# Altium schematic sheet Schematic.SchDoc(Schematic)
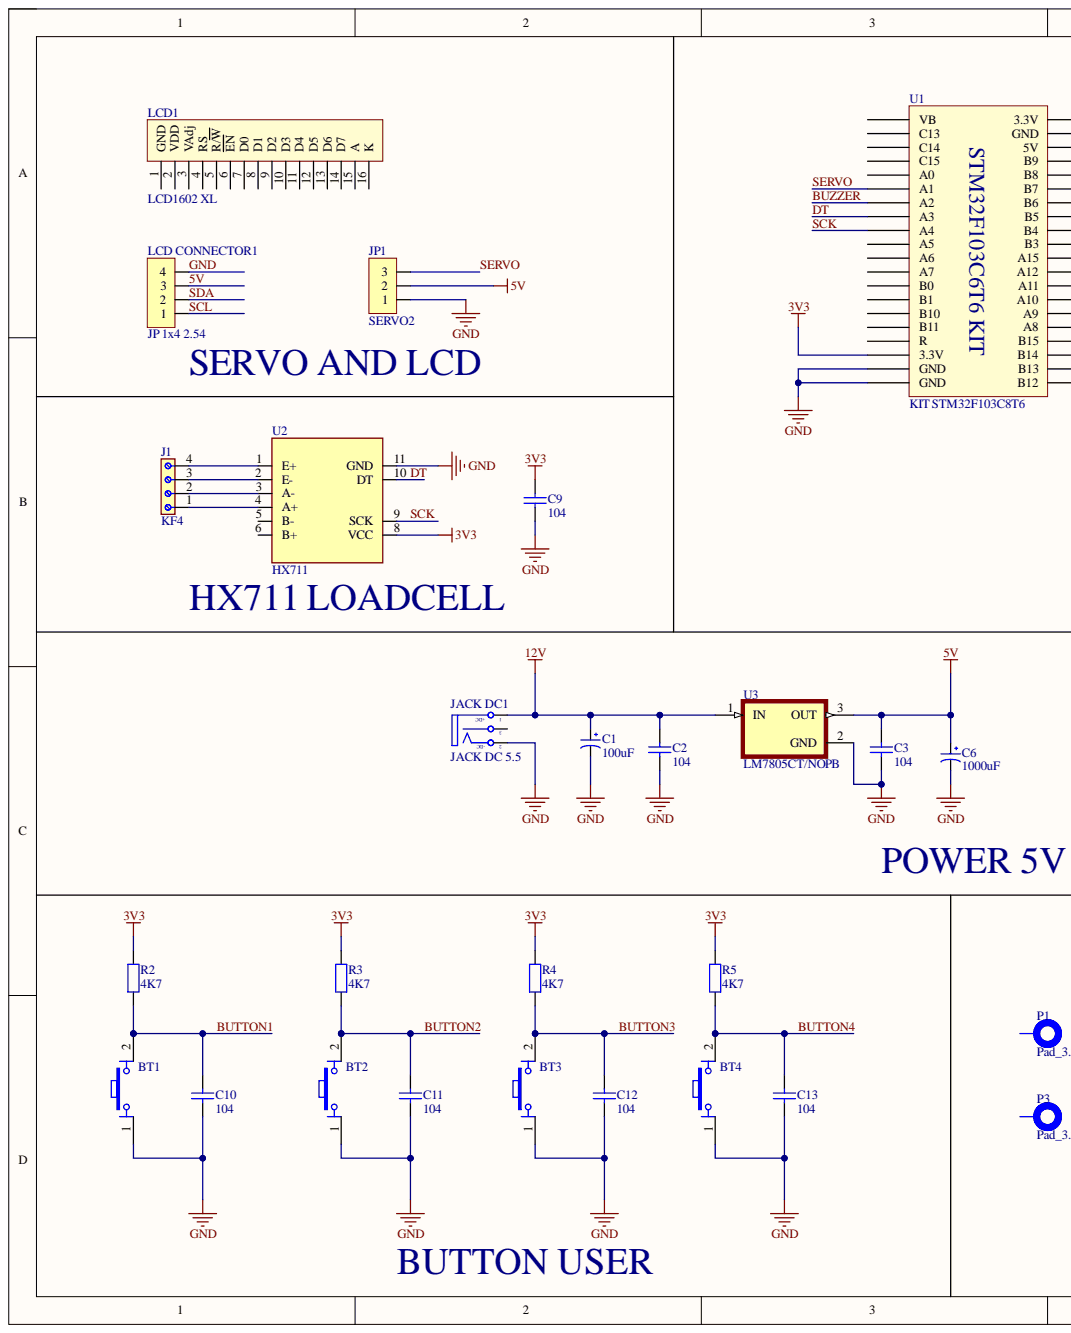
[diagram p0: Schematic.SchDoc, left]
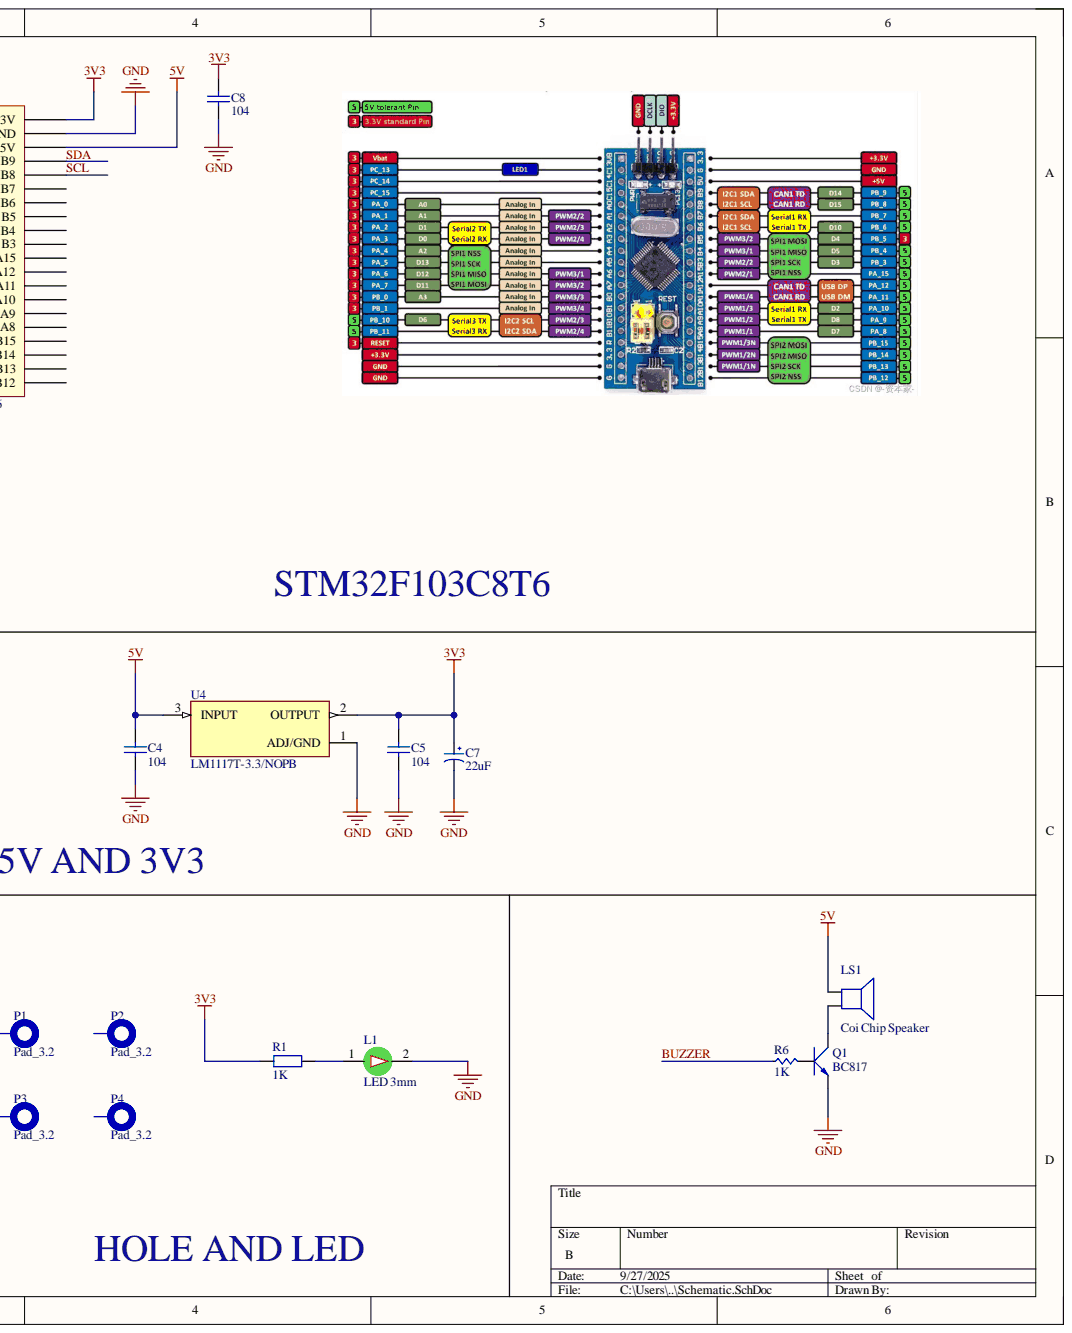
[diagram p1: Schematic.SchDoc, right]

## Parts
BT1  Nut Nhan 4 Chan Cam 6x6  [Nut Nhan 4 Chan Cam 6x6]  (value not drawn)
BT2  Nut Nhan 4 Chan Cam 6x6  [Nut Nhan 4 Chan Cam 6x6]  (value not drawn)
BT3  Nut Nhan 4 Chan Cam 6x6  [Nut Nhan 4 Chan Cam 6x6]  (value not drawn)
BT4  Nut Nhan 4 Chan Cam 6x6  [Nut Nhan 4 Chan Cam 6x6]  (value not drawn)
C1  100uF  [tu hoa 5mm]
C2  104  [tu gom]
C3  104  [tu gom]
C4  104  [tu gom]
C5  104  [tu gom]
C6  1000uF  [tu hoa 10mm]
C7  22uF  [tu hoa 5mm]
C8  104  [tu gom]
C9  104  [tu gom]
C10  104  [tu gom]
C11  104  [tu gom]
C12  104  [tu gom]
C13  104  [tu gom]
J1  KF4  [4P 5.08]
JACK_DC1  JACK DC 5.5  [JACK DC 5.5]
JP1  SERVO2  [JP 1x3 DT]
L1  LED 3mm  [LED-3MM-GREEN]
LCD1  LCD1602 XL  [LCD1602 with Jump]
LCD_CONNECTOR1  JP 1x4 2.54  [JP 1x4 DC]
LS1  Coi Chip Speaker  [Coi Chip Speacker]
P1  Pad_3.2  [PCBComponent_1]
P2  Pad_3.2  [PCBComponent_1]
P3  Pad_3.2  [PCBComponent_1]
P4  Pad_3.2  [PCBComponent_1]
Q1  BC817  [SOT-23]
R1  1K  [tro vach 1/2w]
R2  4K7  [tro vach 1/4w]
R3  4K7  [tro vach 1/4w]
R4  4K7  [tro vach 1/4w]
R5  4K7  [tro vach 1/4w]
R6  1K  [RES 0805]
U1  KIT STM32F103C8T6  [STM32F103 KIT]
U2  HX711  [HX711]
U3  LM7805CT/NOPB  [LM7805]
U4  LM1117T-3.3/NOPB  [TO254P1054X470X1955-3]

## Nets
3V3: C5.1 C7.1 C8.1 C9.1 R1.1 R2.2 R3.2 R4.2 R5.2 U1.20(3.3V) U1.38(3.3V) U2.8(VCC) U4.2
12V: C1.1 C2.1 JACK_DC1.1 U3.1
5V: C3.1 C4.1 C6.1 JP1.2 LCD_CONNECTOR1.3 LS1.1 U1.18(5V) U3.3 U4.3
BUTTON1: BT1.2 C10.1 R2.1
BUTTON2: BT2.2 C11.1 R3.1
BUTTON3: BT3.2 C12.1 R4.1
BUTTON4: BT4.2 C13.1 R5.1
BUZZER: R6.1 U1.27(A2)
DT: U1.28(A3) U2.10(DT)
GND: BT1.1 BT2.1 BT3.1 BT4.1 C1.2 C2.2 C3.2 C4.2 C5.2 C6.2 C7.2 C8.2 C9.2 C10.2 C11.2 C12.2 C13.2 JACK_DC1.2 JP1.1 L1.2 LCD_CONNECTOR1.4 Q1.2 U1.19(GND) U1.39(GND) U1.40(GND) U2.11(GND) U3.2 U4.1
SCK: U1.29(A4) U2.9(SCK)
SCL: LCD_CONNECTOR1.1 U1.16(B8)
SDA: LCD_CONNECTOR1.2 U1.17(B9)
SERVO: JP1.3 U1.26(A1)
NetJ1_1: J1.1 U2.4(A+)
NetJ1_2: J1.2 U2.3(A-)
NetJ1_3: J1.3 U2.2(E-)
NetJ1_4: J1.4 U2.1(E+)
NetL1_1: L1.1 R1.2
NetLS1_2: LS1.2 Q1.3
NetQ1_1: Q1.1 R6.2

Not connected: JACK_DC1 3; LCD1 1(GND) 2(VDD) 3(VAdj) 4(RS) 5(R/W) 6(EN) 7(D0) 8(D1) 9(D2) 10(D3) 11(D4) 12(D5) 13(D6) 14(D7) 15(A) 16(K); P1 0; P2 0; P3 0; P4 0; U1 1(B12) 2(B13) 3(B14) 4(B15) 5(A8) 6(A9) 7(A10) 8(A11) 9(A12) 10(A15) 11(B3) 12(B4) 13(B5) 14(B6) 15(B7) 21(VB) 22(C13) 23(C14) 24(C15) 25(A0) 30(A5) 31(A6) 32(A7) 33(B0) 34(B1) 35(B10) 36(B11) 37(R); U2 5(B-) 6(B+)

## Library data of the parts
BT1-BT4 = Nut Nhan 4 Chan Cam 6x6: Nut Nhan 4 Chan 6x6mm; Ma_Hang: MH004584; Ten: Nút Nhấn 4 Chân 6x6x5MM DIP; Component Kind: Standard; Library Name: Altium_Lib_by_KhueNguyenCreator.IntLib
C1 = Tu Hoa 100uF 25V 6x11: Cắm; Don_Vi: Con; Ma_Hang: MH001351; So_Chan: 2; Ten_Linh_Kien: Tụ Hóa 100uF 25V 6x11MM; Chung_Loai: Cắm; Component Kind: Standard; Library Name: Altium_Lib_by_KhueNguyenCreator.IntLib
C2-C5 C8-C13 = Tu gom 104 50V: Cắm; Don_Vi: Con; Ma_Hang: MH004761; So_Chan: 2; Ten_Linh_Kien: Tụ gốm 104 100nF 50V; Chung_Loai: Cắm; Component Kind: Standard; Library Name: Altium_Lib_by_KhueNguyenCreator.IntLib
C6 = Tu Hoa 1000uF 10V 10x12: Cắm; Don_Vi: Con; Ma_Hang: MH006614; So_Chan: 2; Ten_Linh_Kien: Tụ Hóa 1000uF 10V 10x12MM; Chung_Loai: Cắm; Component Kind: Standard; Library Name: Altium_Lib_by_KhueNguyenCreator.IntLib
C7 = Tu Hoa 22uF 25V 5x11: Cắm; Don_Vi: Con; Ma_Hang: MH006858; So_Chan: 2; Ten_Linh_Kien: Tụ Hóa 22uF 25V; Chung_Loai: Cắm; Component Kind: Standard; Library Name: Altium_Lib_by_KhueNguyenCreator.IntLib
J1 = 691244710004: 4 Position Wire to Board Terminal Block Horizontal with Board 0.197 (5.00mm) Through Hole; MF: WÃ¼rth Elektronik; Check_prices: https://www.snapeda.com/parts/691244710004/W%25C3%25BCrth+Elektronik+Midcom/view-part/?ref=eda; Component Kind: Standard; Library Name: 691244710004.IntLib; MP: 691244710004; Package: None; SnapEDA_Link: https://www.snapeda.com/parts/691244710004/W%25C3%25BCrth+Elektronik+Midcom/view-part/?ref=snap
JACK_DC1 = JACK DC 5.5: Value: Jac DC; Component Kind: Standard; Description: JACK DC 5.5; Library Name: Altium_Lib_by_KhueNguyenCreator.IntLib
JP1 = JP 1x3 2.54 Duc Thang: Cắm; Don_Vi: Con; Ma_Hang: MH000903; Ten_Linh_Kien: Jump Đơn Đực 2.54MM 1x40P Thẳng 11MM; Chung_Loai: Cắm; Component Kind: Standard; Library Name: Altium_Lib_by_KhueNguyenCreator.IntLib
L1 = LED 3mm Xanh La: Cắm; Don_Vi: Con; Ma_Hang: MH001054; Ten_Linh_Kien: Led 3MM Phủ Xanh (10c); Chung_Loai: Cắm; Component Kind: Standard; Library Name: Altium_Lib_by_KhueNguyenCreator.IntLib
LCD1 = LCD1602 XL: LCD 1602 Xanh Lá; CHIP: HD44780; Ma_Hang: 67HZ; NCC: NSHOP; Ten: Màn hình LCD 1602 Xanh Lá; Component Kind: Standard; Library Name: Altium_Lib_by_KhueNguyenCreator.IntLib
LCD_CONNECTOR1 = JP 1x4 2.54 Duc Cong: Cắm; Don_Vi: Con; Ma_Hang: MH000904; Ten_Linh_Kien: Jump Đơn Đực 2.54MM 1x40P Cong Đứng; Chung_Loai: Cắm; Component Kind: Standard; Library Name: Altium_Lib_by_KhueNguyenCreator.IntLib
LS1 = Coi Chip Speaker: Còi chip; Component Kind: Standard; Library Name: Altium_Lib_by_KhueNguyenCreator.IntLib
P1-P4 = Pad_3.2mm: Component Kind: Standard; Library Name: TuanHieu.SchLib
Q1 = Trans C1815 HF SMD: SOT-23; Value: C9014; Component Kind: Standard; Library Name: Altium_Lib_by_KhueNguyenCreator.IntLib
R1 = Tro Vach 1/2W 5% 0.33R: Cắm; Don_Vi: Con; Ma_Hang: MH007239; So_Chan: 2; Ten_Linh_Kien: Trở 5% 1/2w 0.33R; Chung_Loai: Cắm; Component Kind: Standard; Library Name: Altium_Lib_by_KhueNguyenCreator.IntLib
R2-R5 = Tro Vach 1/4W 1% 7.5R: Cắm; Don_Vi: Con; Ma_Hang: MH006343; So_Chan: 2; Ten_Linh_Kien: Trở Vạch 1/4w 1% 7.5R; Chung_Loai: Cắm; Component Kind: Standard; Library Name: Altium_Lib_by_KhueNguyenCreator.IntLib
R6 = RES 0805: RES SMD 0805; Manufacturer: Vishay Dale; Manufacturer Part Number: CRCW040210K0FKED; Power (Watts): 0.063W, 1/16W; Resistance (Ohms): 10k; Temperature Coefficient: ±100ppm/°C; Tolerance: ±1%; Category: Resistors; Component Kind: Standard; ComponentLink1Description: http://www.vishay.com/docs/20035/dcrcwe3.pdf; ComponentLink1URL: http://www.vishay.com/docs/20035/dcrcwe3.pdf; Composition: Thick Film; Features: Automotive AEC-Q200; Height: 0.016" (0.40mm); Number of Terminations: 2; Operating Temperature: -55°C ~ 155°C; Package / Case: 0402 (1005 Metric); Packaging: Cut Tape (CT); Pricing 1: 10=0.083, 50=0.0444, 200=0.0255, 1000=0.0174 (USD); RoHS: RoHS Compliant; Size / Dimension: 0.039" L x 0.020" W (1.00mm x 0.50mm); Stock 1: 2392462; Supplier 1: Digi-Key; Supplier Device Package: 0402 (1005 Metric); Supplier Part Number 1: 541-10.0KLCT-ND; Library Name: Altium_Lib_by_KhueNguyenCreator.IntLib
U1 = STM32f103 Bluepill: KIT STM32F103C8T6 Bluepill; Component Kind: Standard; Library Name: Altium_Lib_by_KhueNguyenCreator.IntLib
U3 = LM7805CT/NOPB: Linear Voltage Regulator IC Positive Fixed 1 Output 1.5A TO-220-3; MF: ON Semiconductor; Availability: In Stock; Check_prices: https://www.snapeda.com/parts/LM7805CT/Onsemi/view-part/?ref=eda; Component Kind: Standard; Library Name: LM7805CT_NOPB.IntLib; MP: LM7805CT; Package: TO-220-3 ON Semiconductor; Price: None; Purchase-URL: https://pricing.snapeda.com/search/part/LM7805CT/?ref=eda; SnapEDA_Link: https://www.snapeda.com/parts/LM7805CT/Onsemi/view-part/?ref=snap
U4 = LM1117T-3.3/NOPB: 800-mA 15-V linear voltage regulator; MF: Texas Instruments; Check_prices: https://www.snapeda.com/parts/LM1117T-3.3/NOPB/Texas+Instruments/view-part/?ref=eda; Component Kind: Standard; Library Name: LM1117T-3.3_NOPB.IntLib; MP: LM1117T-3.3/NOPB; Package: TO-220-3 Texas Instruments; SnapEDA_Link: https://www.snapeda.com/parts/LM1117T-3.3/NOPB/Texas+Instruments/view-part/?ref=snap
U2 = HX711: Component Kind: Standard; Library Name: Schlib1.SchLib
